# Altium schematic sheet top.SchDoc
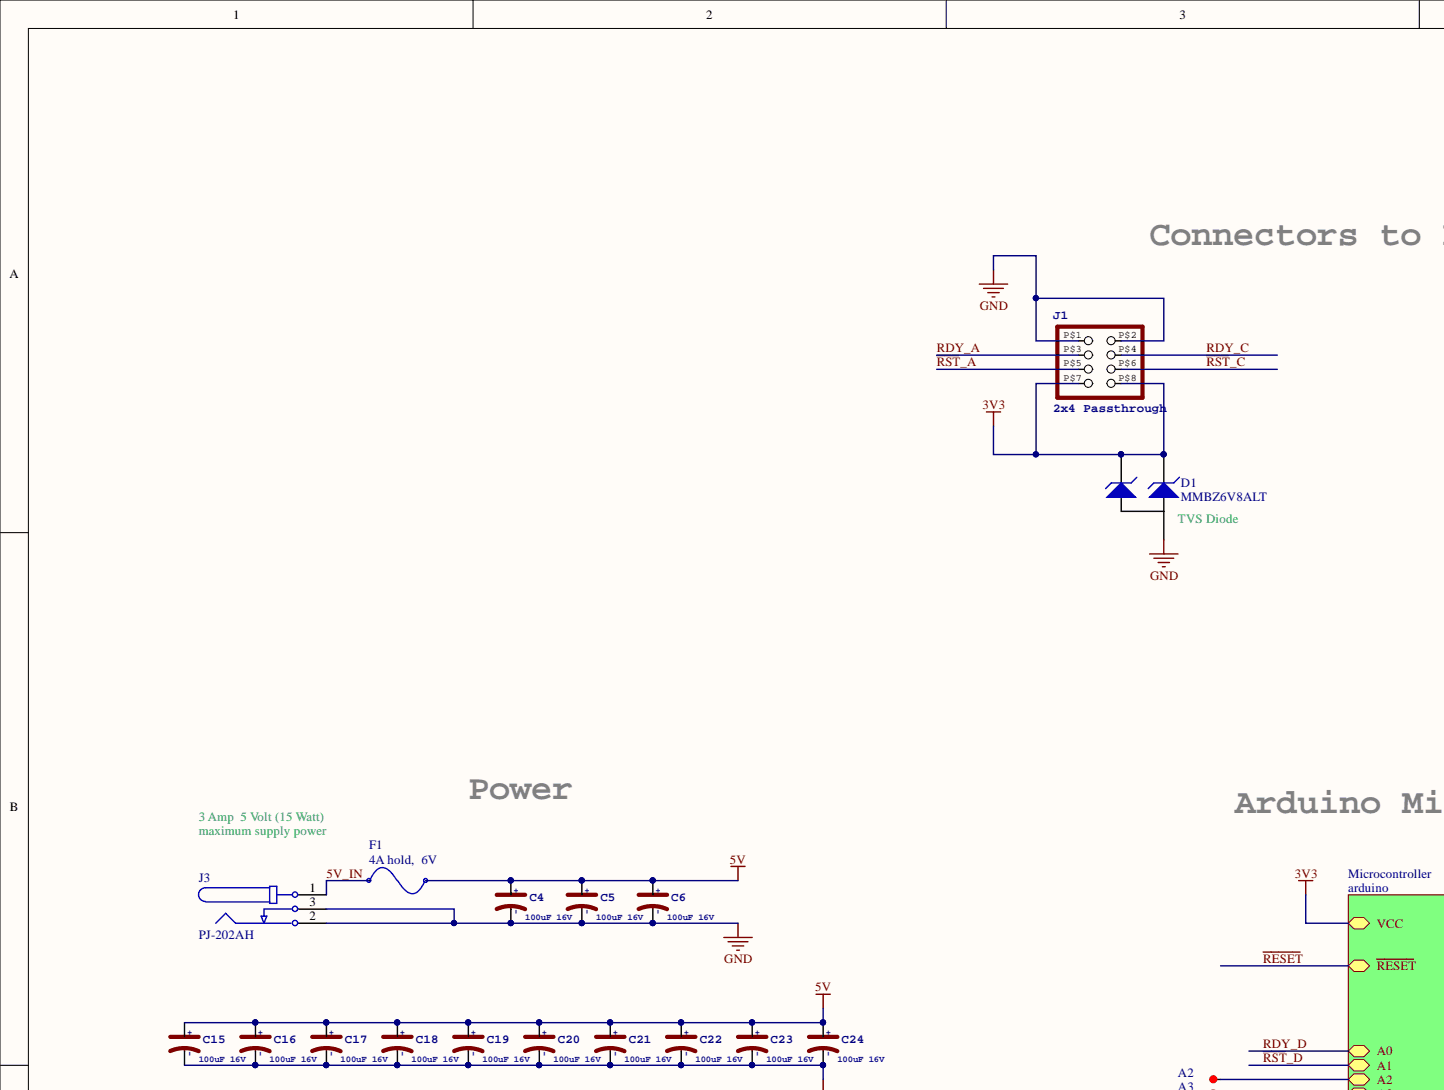
[diagram p0: top.SchDoc, top left]
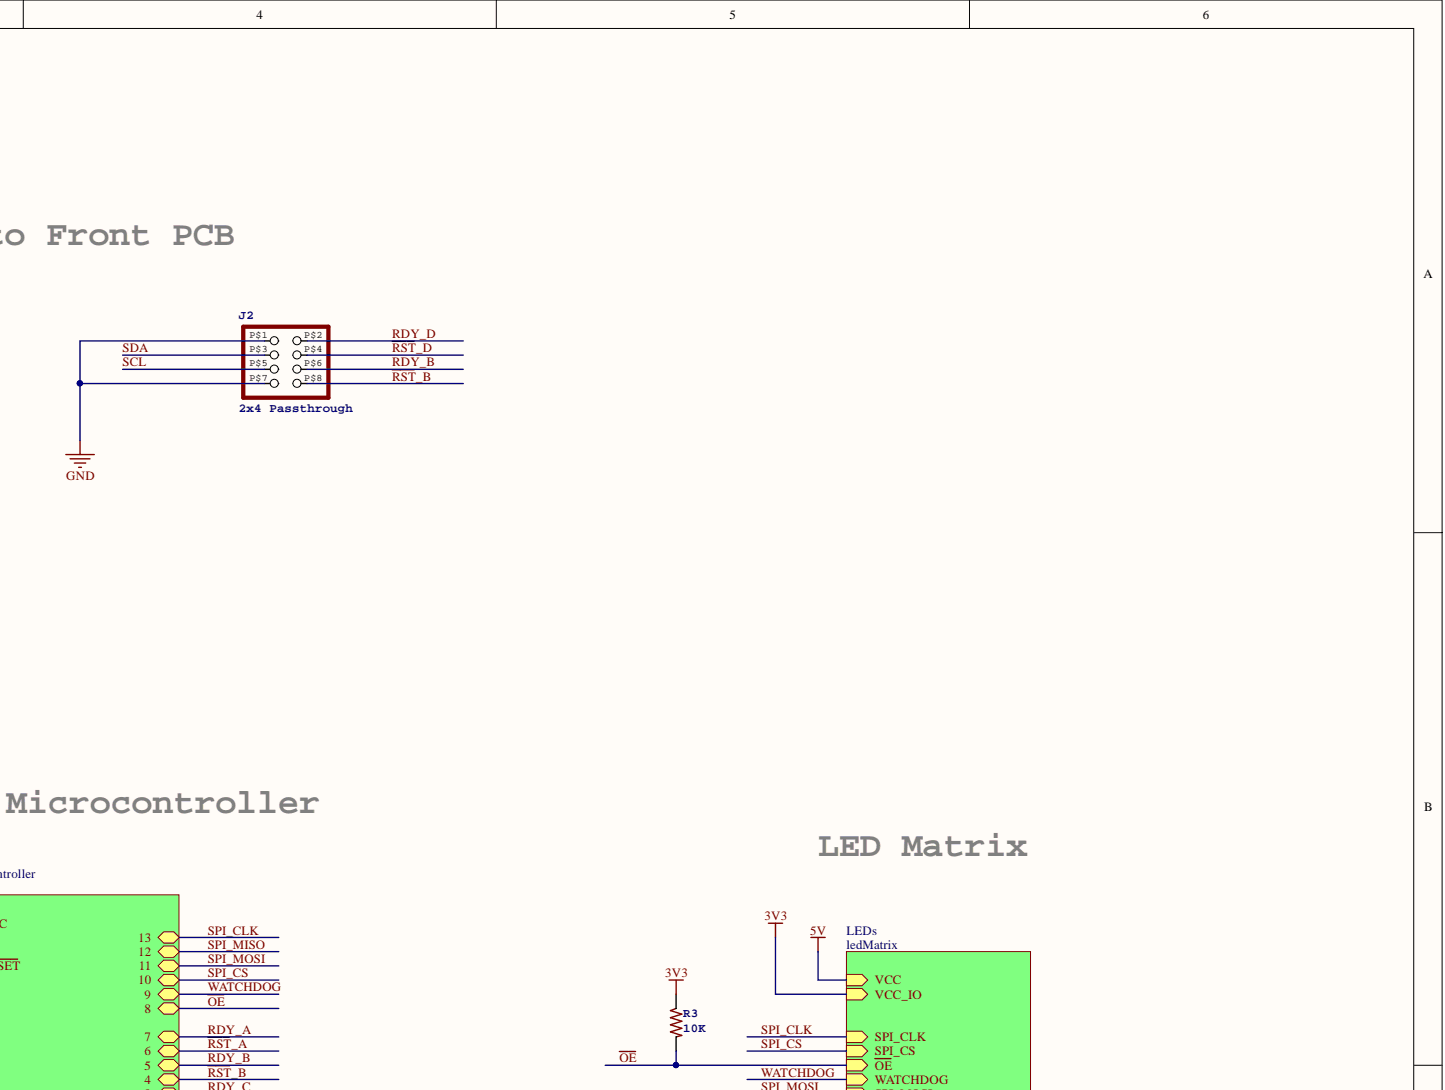
[diagram p1: top.SchDoc, top right]
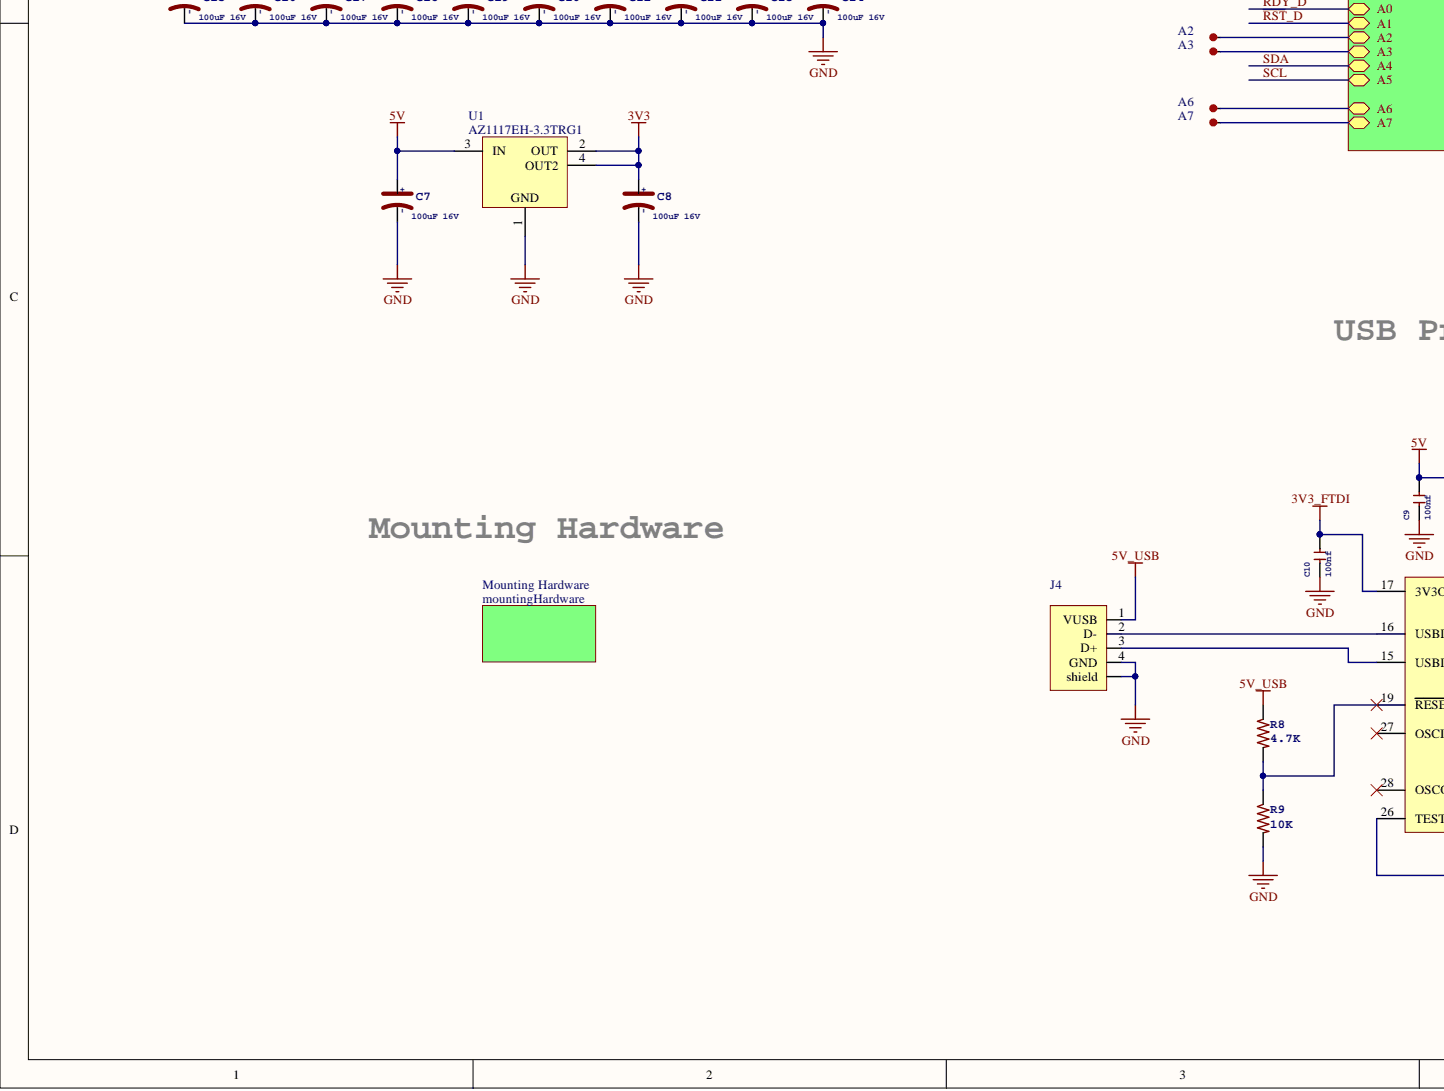
[diagram p2: top.SchDoc, bottom left]
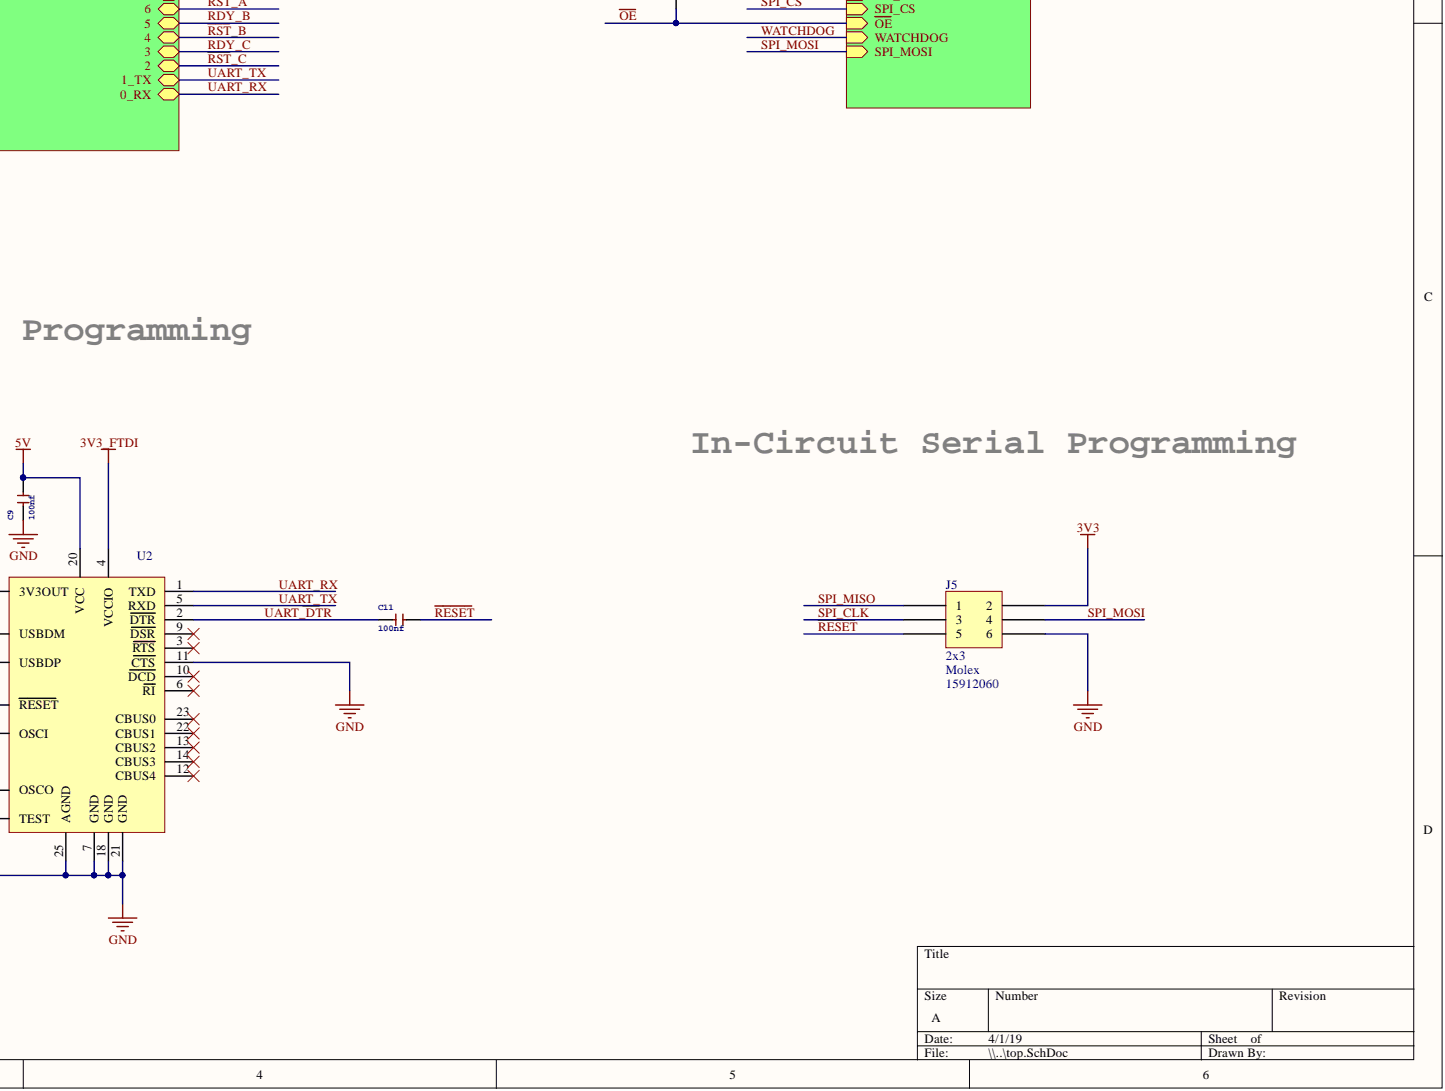
[diagram p3: top.SchDoc, bottom right]

## Parts
A2  TP_SMT_31mils  [TP-31mil]  (value not drawn)  (no BOM)
A3  TP_SMT_31mils  [TP-31mil]  (value not drawn)  (no BOM)
A6  TP_SMT_31mils  [TP-31mil]  (value not drawn)  (no BOM)
A7  TP_SMT_31mils  [TP-31mil]  (value not drawn)  (no BOM)
C4  100uF 16V  [Capacitor-D]
C5  100uF 16V  [Capacitor-D]
C6  100uF 16V  [Capacitor-D]
C7  100uF 16V  [Capacitor-D]
C8  100uF 16V  [Capacitor-D]
C9  100nf  [0603]
C10  100nf  [0603]
C11  100nf  [0603]
C15  100uF 16V  [Capacitor-D]
C16  100uF 16V  [Capacitor-D]
C17  100uF 16V  [Capacitor-D]
C18  100uF 16V  [Capacitor-D]
C19  100uF 16V  [Capacitor-D]
C20  100uF 16V  [Capacitor-D]
C21  100uF 16V  [Capacitor-D]
C22  100uF 16V  [Capacitor-D]
C23  100uF 16V  [Capacitor-D]
C24  100uF 16V  [Capacitor-D]
D1  MMBZ6V8ALT  [SOT23]
F1  4A hold,  6V  [1206]
J1  2x4 Passthrough  [71991-304HLF]
J2  2x4 Passthrough  [71991-304HLF]
J3  2.1mm ID 5.5mm OD  [PJ-202AH]  (value not drawn)
J4  (no value)  [UJ2-BH-1-TH]
J5  2x3  Manufacturer: Molex  PartNumber: 15912060  [HDR_2x3_SMT]
R3  10K  [0603]
R8  4.7K  [0603]
R9  10K  [0603]
U1  PartNumber: AZ1117EH-3.3TRG1  [SOT223]  (hidden comment: 3.3V)
U2  (no value)  [SSOP-28]

## Nets
3V3: C8.+ D1.1 D1.2 J1.P$7 J1.P$8 J5.2 R3.1 U1.2(OUT) U1.4(OUT2)
3V3_FTDI: C10.2 U2.4(VCCIO) U2.17(3V3OUT)
5V: C4.+ C5.+ C6.+ C7.+ C9.2 C15.+ C16.+ C17.+ C18.+ C19.+ C20.+ C21.+ C22.+ C23.+ C24.+ F1.2 U1.3(IN) U2.20(VCC)
5V_USB: J4.1(VUSB) R8.1
GND: C4.- C5.- C6.- C7.- C8.- C9.1 C10.1 C15.- C16.- C17.- C18.- C19.- C20.- C21.- C22.- C23.- C24.- D1.3 J1.P$1 J1.P$2 J2.P$1 J2.P$7 J3.2 J3.3 J4.4(GND) J4.shield J5.6 R9.2 U1.1 U2.7(GND) U2.11(CTS) U2.18(GND) U2.21(GND) U2.25(AGND) U2.26(TEST)
5V_IN: F1.1 J3.1
~{OE}: R3.2
~{RESET}: C11.2 J5.5
~{RST}_A: J1.P$5
~{RST}_B: J2.P$8
~{RST}_C: J1.P$6
~{RST}_D: J2.P$4
RDY_A: J1.P$3
RDY_B: J2.P$6
RDY_C: J1.P$4
RDY_D: J2.P$2
SCL: J2.P$5
SDA: J2.P$3
SPI_CLK: J5.3
SPI_MISO: J5.1
SPI_MOSI: J5.4
UART_~{DT}R: C11.1 U2.2(DTR)
UART_RX: U2.1(TXD)
UART_TX: U2.5(RXD)
NetA2_1: A2.1
NetA3_1: A3.1
NetA6_1: A6.1
NetA7_1: A7.1
NetJ4_2: J4.2(D-) U2.16(USBDM)
NetJ4_3: J4.3(D+) U2.15(USBDP)
NetR8_2: R8.2 R9.1 U2.19(RESET)
NetU2_3: U2.3(RTS)
NetU2_6: U2.6(RI)
NetU2_9: U2.9(DSR)
NetU2_10: U2.10(DCD)
NetU2_12: U2.12(CBUS4)
NetU2_13: U2.13(CBUS2)
NetU2_14: U2.14(CBUS3)
NetU2_22: U2.22(CBUS1)
NetU2_23: U2.23(CBUS0)
NetU2_27: U2.27(OSCI)
NetU2_28: U2.28(OSCO)
SPI_CS:
WATCHDOG:

## Library data of the parts
A2 A3 A6 A7 = TP_SMT_31mils: 31 mil pad meant for 50 mil spacing.; Reviewer: BDF; Library Name: PCB-Features.SchLib; Reviewed: 4/6/2017
C4-C8 C15-C24 = ALUMINUM-SMD-47UF-25V(D6.3-H5.3MM): 100uF 16V Electrolytic Capacitor; Manufacturer: Panasonic Electronics Components; PartNumber: EEE-1CA470WR; +: +; -: -; Component Kind: Standard; VALUE: 100uF 16V; Library Name: Seeed-Capacitor.IntLib
C9-C11 = CERAMIC-100NF-50V-10%-X7R(0603): 100nf Ceramic Capacitor; Manufacturer: Yageo; PartNumber: CC0603ZRY5V9BB104; Substitute_Allowed: YES; Component Kind: Standard; Value: 100nF; Library Name: Seeed-Capacitor.IntLib
D1 = MMBZ6V8ALT: TVS DIODE 4.5V 9.6V SOT23-3; Manufacturer: On Semiconductor; Substitute_Allowed: YES; Component Kind: Standard; Library Name: Diodes.SchLib; PartNumber: MMBZ6V8ALT
F1 = NANOSMD400LR-2: PTC RESET FUSE 6V 4A 1206; Manufacturer: Littelfuse Inc.; Component Kind: Standard; Library Name: Miscellaneous.SchLib; PartNumber: NANOSMD400LR-2; Value: 4A hold,  6V
J1 J2 = PINHD-2X4PASS4X2: Board-to-Board pass-through connector; Manufacturer: Amphenol; Component Kind: Standard; PartNumber: 71991-304HLF; Value: 2x4 Passthrough; Library Name: SimonePads.IntLib
J3 = PJ-202AH: Barrel Jack 2.1mm ID 5.5mm OD; Manufacturer: CUI Inc.; Substitute_Allowed: YES; Substitute_Requirement: Must not exceed height; Component Kind: Standard; Library Name: Connectors.SchLib; PartNumber: PJ-202AH; Value: 2.1mm ID 5.5mm OD
J4 = UJ2-BH-1-TH: USB Standard Type-B; Manufacturer: CUI Inc.; Substitute_Allowed: YES; Substitute_Requirement: Must not exceed height; Component Kind: Standard; Library Name: Connectors.SchLib; PartNumber: UJ2-BH-1-TH
J5 = Header 2x3 SMT: Component Kind: Standard; Description: Header 2x3 SMT; Library Name: Connectors.SchLib; Value: 2x3
R3 R9 = R-US_R0603: Manufacturer: Yageo; PartNumber: RC0603JR-0710KL; Substitute_Allowed: YES; Component Kind: Standard; Description: 10k; Library Name: rcl.IntLib; SPICEPREFIX: R; Value: 10K
R8 = R-US_R0603: Manufacturer: Yageo; PartNumber: RC0603JR-074K7L; Substitute_Allowed: YES; Component Kind: Standard; Description: 4.7K; Library Name: rcl.IntLib; SPICEPREFIX: R; Value: 4.7K
U1 = AZ1117EH-3.3TRG: 3.3V Output LDO; Manufacturer: Diodes Inc; Substitute_Allowed: YES; Substitute_Requirement: 1 Amp output; Component Kind: Standard; Library Name: Power.SchLib; Value: 3.3V
U2 = FT232RL: USB to UART adapter; Manufacturer: FTDI; Component Kind: Standard; Library Name: Integrated-Circuits.SchLib; PartNumber: FT232RL
